# Altium schematic sheet Micro.SchDoc
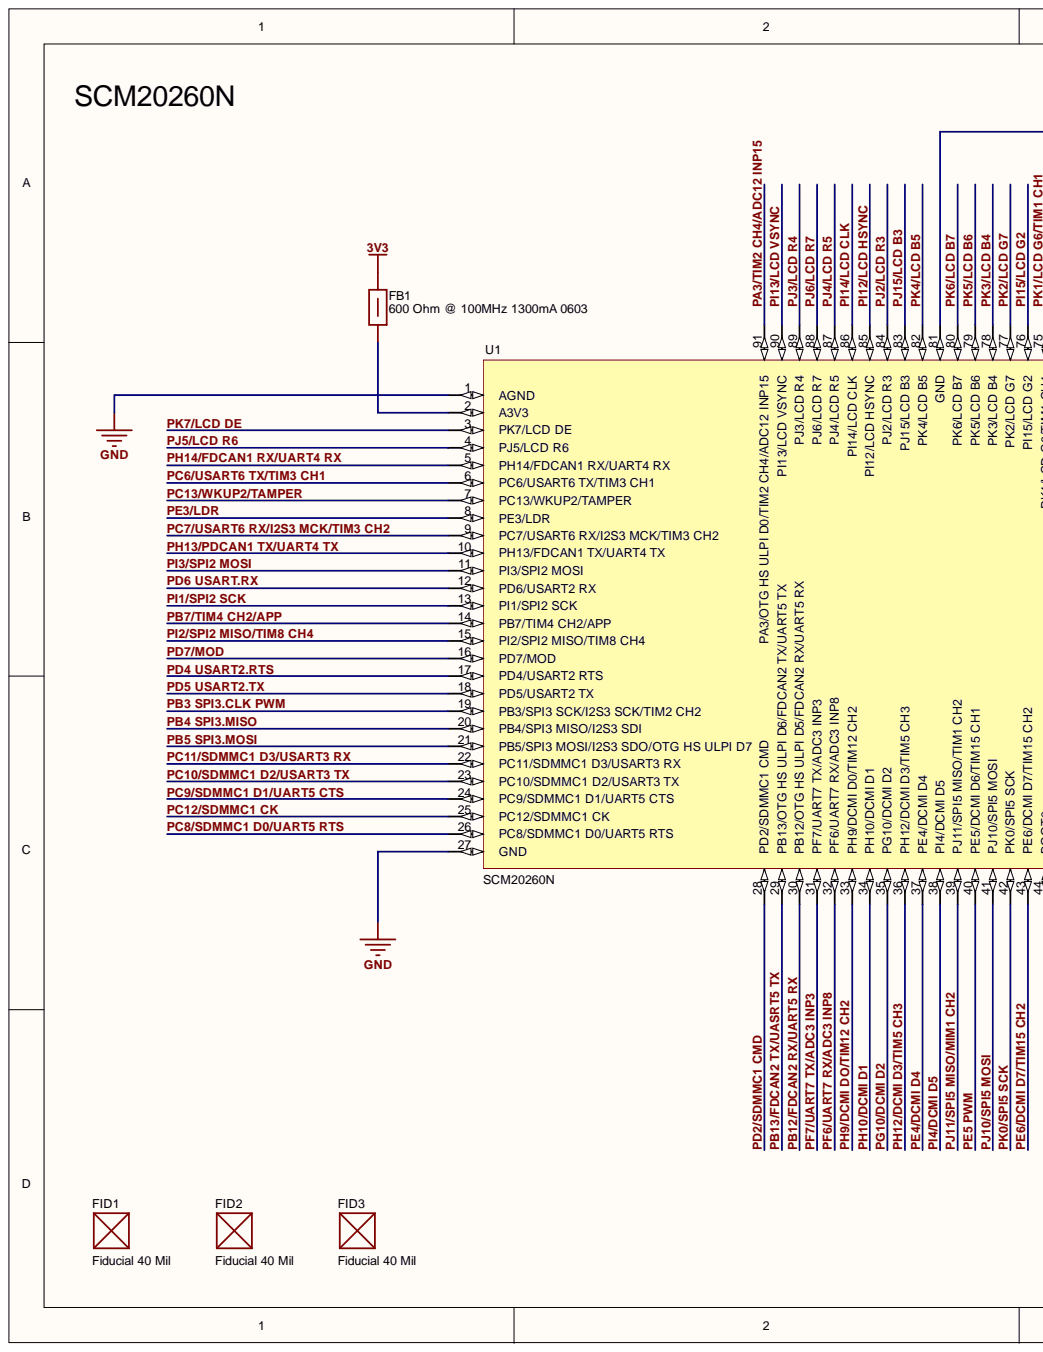
[diagram p0: Micro.SchDoc, left]
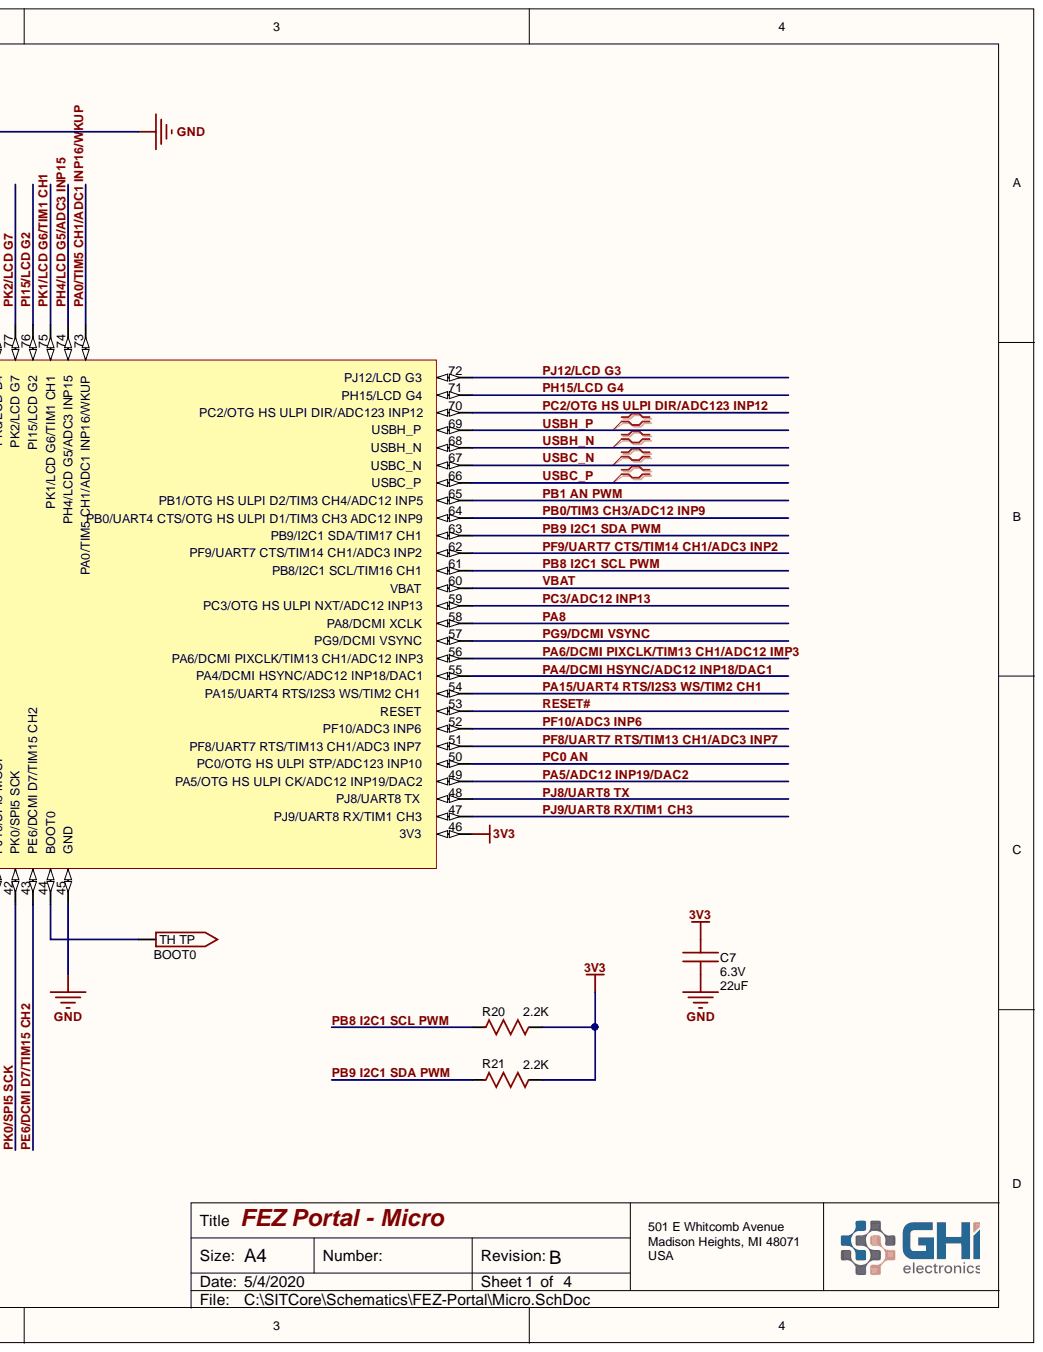
[diagram p1: Micro.SchDoc, right]

## Parts
BOOT0  TH Testpad 32mil (32mil ar)  [32mil TH]  (value not drawn)  (no BOM)
C7  value 22uF  Capacitance: 22uF  Voltage: 6.3V  [C0805]  (hidden comment: 0805 Cap 22uF)
FB1  600 Ohm @ 100MHz 1300mA 0603  [R0603 (Metric 1608)]
FID1  Fiducial 40 Mil  [Fiducial 40 Mil]  (no BOM)
FID2  Fiducial 40 Mil  [Fiducial 40 Mil]  (no BOM)
FID3  Fiducial 40 Mil  [Fiducial 40 Mil]  (no BOM)
R20  value 2.2K  Resistance: 2.2K  [R0603 (1608 Metric)]  (hidden comment: 0603 2.2K)
R21  value 2.2K  Resistance: 2.2K  [R0603 (1608 Metric)]  (hidden comment: 0603 2.2K)
U1  SCM20260N  [SCM20260N]

## Nets
3V3: C7.2 FB1.2 R20.2 R21.2 U1.46(3V3)
GND: C7.1 U1.1(AGND) U1.27(GND) U1.45(GND) U1.81(GND)
PA0/TIM5 CH1/ADC1 INP16/WKUP: U1.73(PA0/TIM5_CH1/ADC1_INP16/WKUP)
PA3/TIM2 CH4/ADC12 INP15: U1.91
PA4/DCMI HSYNC/ADC12 INP18/DAC1: U1.55(PA4/DCMI_HSYNC/ADC12_INP18/DAC1)
PA5/ADC12 INP19/DAC2: U1.49(PA5/OTG_HS_ULPI_CK/ADC12_INP19/DAC2)
PA6/DCMI PIXCLK/TIM13 CH1/ADC12 IMP3: U1.56(PA6/DCMI_PIXCLK/TIM13_CH1/ADC12_INP3)
PA8: U1.58(PA8/DCMI_XCLK)
PA15/UART4 RTS/I2S3 WS/TIM2 CH1: U1.54(PA15/UART4_RTS/I2S3_WS/TIM2_CH1)
PB0/TIM3 CH3/ADC12 INP9: U1.64(PB0/UART4_CTS/OTG_HS_ULPI_D1/TIM3_CH3_ADC12_INP9)
PB1 AN PWM: U1.65(PB1/OTG_HS_ULPI_D2/TIM3_CH4/ADC12_INP5)
PB3 SPI3.CLK PWM: U1.19(PB3/SPI3_SCK/I2S3_SCK/TIM2_CH2)
PB4 SPI3.MISO: U1.20(PB4/SPI3_MISO/I2S3_SDI)
PB5 SPI3.MOSI: U1.21(PB5/SPI3_MOSI/I2S3_SDO/OTG_HS_ULPI_D7)
PB7/TIM4 CH2/APP: U1.14(PB7/TIM4_CH2/APP)
PB8 I2C1 SCL PWM: R20.1 U1.61(PB8/I2C1_SCL/TIM16_CH1)
PB9 I2C1 SDA PWM: R21.1 U1.63(PB9/I2C1_SDA/TIM17_CH1)
PB12/FDCAN2 RX/UART5 RX: U1.30
PB13/FDCAN2 TX/UASRT5 TX: U1.29
PC0 AN: U1.50(PC0/OTG_HS_ULPI_STP/ADC123_INP10)
PC2/OTG HS ULPI DIR/ADC123 INP12: U1.70(PC2/OTG_HS_ULPI_DIR/ADC123_INP12)
PC3/ADC12 INP13: U1.59(PC3/OTG_HS_ULPI_NXT/ADC12_INP13)
PC6/USART6 TX/TIM3 CH1: U1.6(PC6/USART6_TX/TIM3_CH1)
PC7/USART6 RX/I2S3 MCK/TIM3 CH2: U1.9(PC7/USART6_RX/I2S3_MCK/TIM3_CH2)
PC8/SDMMC1 D0/UART5 RTS: U1.26(PC8/SDMMC1_D0/UART5_RTS)
PC9/SDMMC1 D1/UART5 CTS: U1.24(PC9/SDMMC1_D1/UART5_CTS)
PC10/SDMMC1 D2/USART3 TX: U1.23(PC10/SDMMC1_D2/USART3_TX)
PC11/SDMMC1 D3/USART3 RX: U1.22(PC11/SDMMC1_D3/USART3_RX)
PC12/SDMMC1 CK: U1.25(PC12/SDMMC1_CK)
PC13/WKUP2/TAMPER: U1.7(PC13/WKUP2/TAMPER)
PD2/SDMMC1 CMD: U1.28(PD2/SDMMC1_CMD)
PD4 USART2.RTS: U1.17(PD4/USART2_RTS)
PD5 USART2.TX: U1.18(PD5/USART2_TX)
PD6 USART.RX: U1.12(PD6/USART2_RX)
PD7/MOD: U1.16(PD7/MOD)
PE3/LDR: U1.8(PE3/LDR)
PE4/DCMI D4: U1.37(PE4/DCMI_D4)
PE5 PWM: U1.40(PE5/DCMI_D6/TIM15_CH1)
PE6/DCMI D7/TIM15 CH2: U1.43(PE6/DCMI_D7/TIM15_CH2)
PF6/UART7 RX/ADC3 INP8: U1.32(PF6/UART7_RX/ADC3_INP8)
PF7/UART7 TX/ADC3 INP3: U1.31(PF7/UART7_TX/ADC3_INP3)
PF8/UART7 RTS/TIM13 CH1/ADC3 INP7: U1.51(PF8/UART7_RTS/TIM13_CH1/ADC3_INP7)
PF9/UART7 CTS/TIM14 CH1/ADC3 INP2: U1.62(PF9/UART7_CTS/TIM14_CH1/ADC3_INP2)
PF10/ADC3 INP6: U1.52(PF10/ADC3_INP6)
PG9/DCMI VSYNC: U1.57(PG9/DCMI_VSYNC)
PG10/DCMI D2: U1.35(PG10/DCMI_D2)
PH4/LCD G5/ADC3 INP15: U1.74(PH4/LCD_G5/ADC3_INP15)
PH9/DCMI DO/TIM12 CH2: U1.33(PH9/DCMI_D0/TIM12_CH2)
PH10/DCMI D1: U1.34(PH10/DCMI_D1)
PH12/DCMI D3/TIM5 CH3: U1.36(PH12/DCMI_D3/TIM5_CH3)
PH13/PDCAN1 TX/UART4 TX: U1.10(PH13/FDCAN1_TX/UART4_TX)
PH14/FDCAN1 RX/UART4 RX: U1.5(PH14/FDCAN1_RX/UART4_RX)
PH15/LCD G4: U1.71(PH15/LCD_G4)
PI1/SPI2 SCK: U1.13(PI1/SPI2_SCK)
PI2/SPI2 MISO/TIM8 CH4: U1.15(PI2/SPI2_MISO/TIM8_CH4)
PI3/SPI2 MOSI: U1.11(PI3/SPI2_MOSI)
PI4/DCMI D5: U1.38(PI4/DCMI_D5)
PI12/LCD HSYNC: U1.85(PI12/LCD_HSYNC)
PI13/LCD VSYNC: U1.90(PI13/LCD_VSYNC)
PI14/LCD CLK: U1.86(PI14/LCD_CLK)
PI15/LCD G2: U1.76(PI15/LCD_G2)
PJ2/LCD R3: U1.84(PJ2/LCD_R3)
PJ3/LCD R4: U1.89(PJ3/LCD_R4)
PJ4/LCD R5: U1.87(PJ4/LCD_R5)
PJ5/LCD R6: U1.4(PJ5/LCD_R6)
PJ6/LCD R7: U1.88(PJ6/LCD_R7)
PJ8/UART8 TX: U1.48(PJ8/UART8_TX)
PJ9/UART8 RX/TIM1 CH3: U1.47(PJ9/UART8_RX/TIM1_CH3)
PJ10/SPI5 MOSI: U1.41(PJ10/SPI5_MOSI)
PJ11/SPI5 MISO/MIM1 CH2: U1.39(PJ11/SPI5_MISO/TIM1_CH2)
PJ12/LCD G3: U1.72(PJ12/LCD_G3)
PJ15/LCD B3: U1.83(PJ15/LCD_B3)
PK0/SPI5 SCK: U1.42(PK0/SPI5_SCK)
PK1/LCD G6/TIM1 CH1: U1.75(PK1/LCD_G6/TIM1_CH1)
PK2/LCD G7: U1.77(PK2/LCD_G7)
PK3/LCD B4: U1.78(PK3/LCD_B4)
PK4/LCD B5: U1.82(PK4/LCD_B5)
PK5/LCD B6: U1.79(PK5/LCD_B6)
PK6/LCD B7: U1.80(PK6/LCD_B7)
PK7/LCD DE: U1.3(PK7/LCD_DE)
RESET#: U1.53(RESET)
USBC_N: U1.67(USBC_N)
USBC_P: U1.66(USBC_P)
USBH_N: U1.68(USBH_N)
USBH_P: U1.69(USBH_P)
VBAT: U1.60(VBAT)
NetBOOT0_1: BOOT0.1 U1.44(BOOT0)
NetFB1_1: FB1.1 U1.2(A3V3)

## Library data of the parts
BOOT0 = TH Testpad 32mil (32mil ar): TH Testpad 32mil (32mil annular ring); Library Name: GHI.SchLib
C7 = 0805 Cap 22uF: 22uF ±20% 6.3V X5R 0805 Multilayer Ceramic Capacitors MLCC - SMD/SMT RoHS; Mfr.Part #: CL21A226MQCLRNC; Category: Ceramic; Component Kind: Standard; LCSC Part #: C307530; Package: 0805; Tolerance: ±20%; Library Name: GHI-Passives.SchLib
FB1 = 600 Ohm @ 100MHz 1300mA 0603 (Metric 1608): Ferrite Bead 600 Ohm @ 100MHz 1300mA 0603 (Metric 1608); GHI Part Number: x; PartNo: BLM18KG601SN1D; DC Resistance: 150 mOhm; Impedance @ 100MHz: 600+-25%; Rated Current (mA @ 85C): 1300; Rated Current (mA @ 125C): 1000; Value: BLM18KG601SN1D; Component Kind: Standard; Component Type: Ferrite Bead; Library Name: GHI Basic Components.SchLib; Package: 0603
R20 R21 = 0603 Res 2.2K: 2.2K ±1% 1/10W ±100ppm/℃ 0603 Chip Resistor - Surface Mount RoHS; Manufacturer: YAGEO; Mfr.Part #: RC0603FR-072K2L; Voltage Rating: *V; Component Kind: Standard; LCSC Part #: C114662; Package: 0603; Power Rating: 1/10W; Resistance Tolerance: ±1%; Library Name: GHI-Passives.SchLib
U1 = SCM20260N: DeviceSetName: G120_1V1; GateName_1: G$1; Component Kind: Standard; SymbolName_1: G120_1V1; Library Name: GHI-Modules.SchLib; LibraryName: GHI
FID1-FID3 = Fiducial 40 Mil: Library Name: GHI.SchLib
